# Altium schematic sheet RES + EBS V2.SchDoc
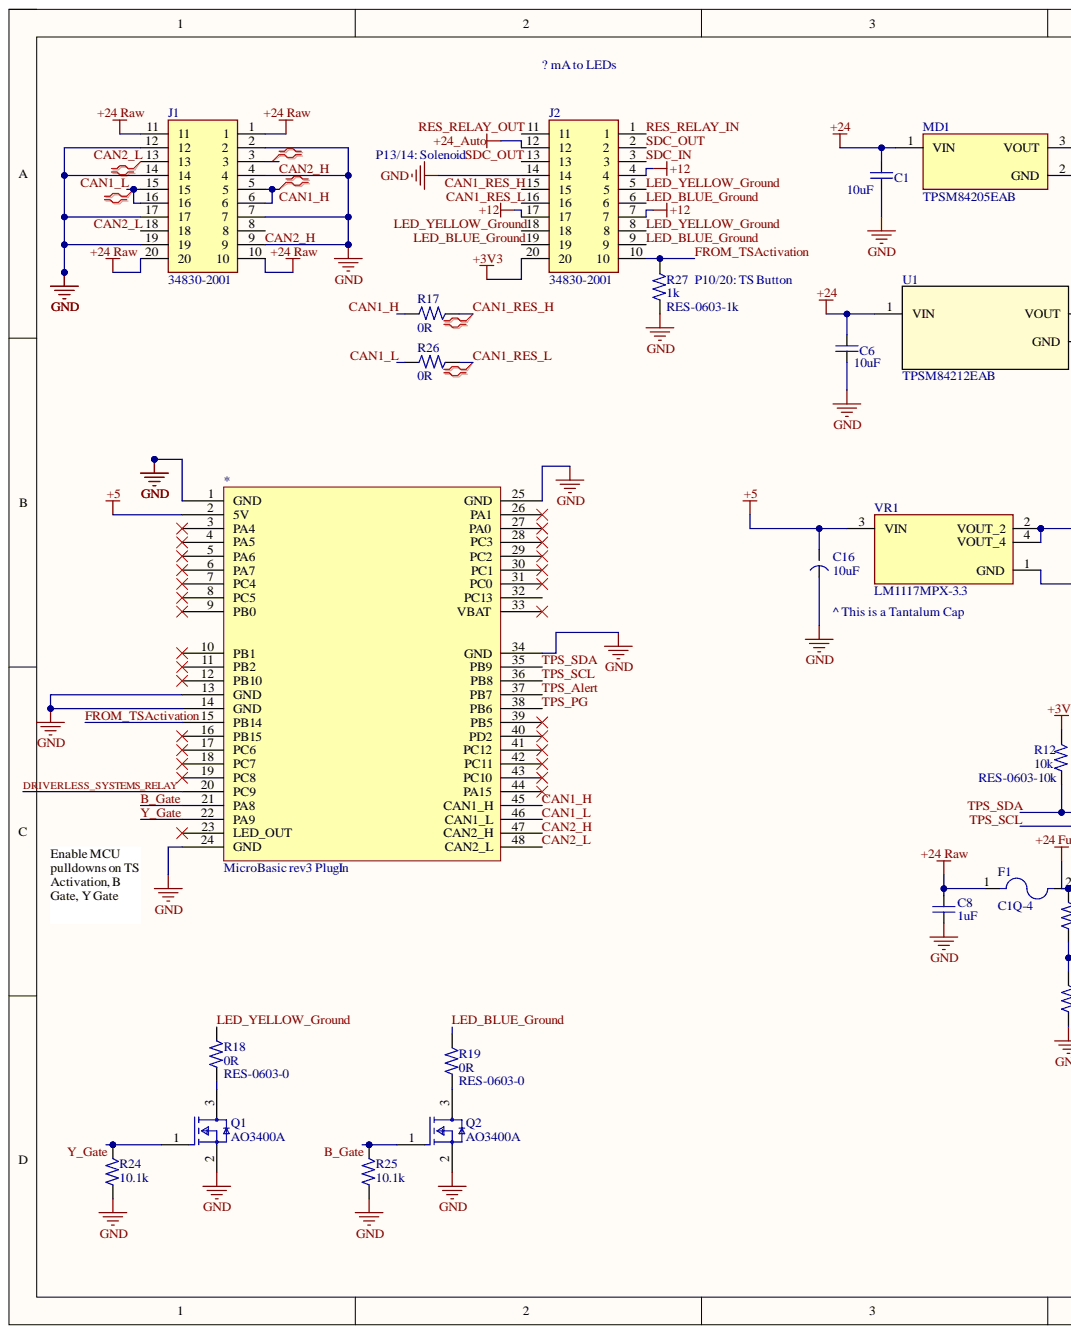
[diagram p0: RES + EBS V2.SchDoc, left]
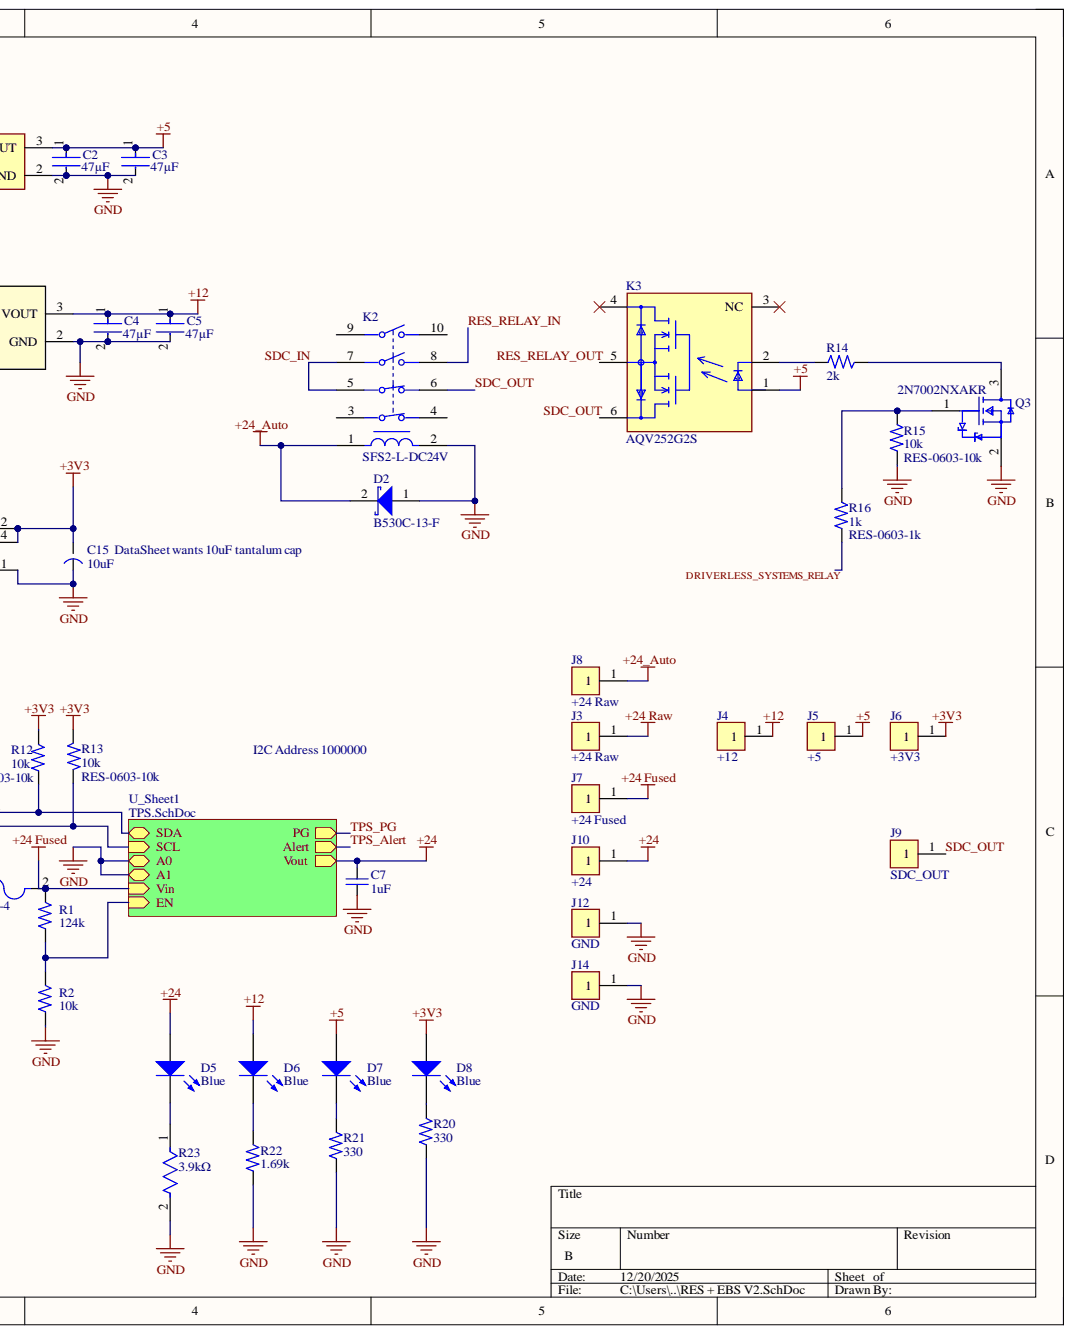
[diagram p1: RES + EBS V2.SchDoc, right]

## Parts
*  MicroBasic rev3 PlugIn  [SFFSTM]
C1  10uF  [C1210]
C2  value 47µF  [FP-GRM32E-0_3-0_2-IPC_C]  (hidden comment: GRM32EC81C476KE15L)
C3  value 47µF  [FP-GRM32E-0_3-0_2-IPC_C]  (hidden comment: GRM32EC81C476KE15L)
C4  value 47µF  [FP-GRM32E-0_3-0_2-IPC_C]  (hidden comment: GRM32EC81C476KE15L)
C5  value 47µF  [FP-GRM32E-0_3-0_2-IPC_C]  (hidden comment: GRM32EC81C476KE15L)
C6  10uF  [C1210]
C7  value 1uF  [CAP0603]  (hidden comment: CAP-0603-1u-50V)
C8  value 1uF  [CAP0603]  (hidden comment: CAP-0603-1u-50V)
C15  value 10uF  Capacitance: 10uF  [FP-TAJA-MFG]  (hidden comment: CAP-TANT-1206-10u-16V)
C16  value 10uF  Capacitance: 10uF  [FP-TAJA-MFG]  (hidden comment: CAP-TANT-1206-10u-16V)
D2  B530C-13-F  [DIOD-SMC-2_V]
D5  Blue  [LED0603]
D6  Blue  [LED0603]
D7  Blue  [LED0603]
D8  Blue  [LED0603]
F1  C1Q-4  [FUSC3215X78N]
J1  34830-2001  [348302001]
J2  34830-2001  [348302001]
J3  +24 Raw  [FP-5001-MFG]
J4  +12  [FP-5001-MFG]
J5  +5  [FP-5001-MFG]
J6  +3V3  [FP-5001-MFG]
J7  +24 Fused  [FP-5001-MFG]
J8  +24 Raw  [FP-5001-MFG]
J9  SDC_OUT  [FP-5001-MFG]
J10  +24  [FP-5001-MFG]
J12  GND  [FP-5001-MFG]
J14  GND  [FP-5001-MFG]
K2  SFS2-L-DC24V  [FP-SFS2-L-DC24V-MFG]
K3  AQV252G2S  [FP-AQV252G2S-IPC_A]
MD1  TPSM84205EAB  [FP-EAB0003A-MFG]
Q1  AO3400A  [FP-SOT23-MFG]
Q2  AO3400A  [FP-SOT23-MFG]
Q3  2N7002NXAKR  [FP-SOT23-IPC_B]
R1  value 124k  [RES0603]  (hidden comment: RES-0603-124k)
R2  RES-0603-10k  value 10k  [RES0603]
R12  RES-0603-10k  value 10k  [RES0603]
R13  RES-0603-10k  value 10k  [RES0603]
R14  value 2k  [RES0603]  (hidden comment: RES-0603-2k)
R15  RES-0603-10k  value 10k  [RES0603]
R16  RES-0603-1k  value 1k  [RES0603]
R17  RES-0603-0  value 0R  [RES0603]
R18  RES-0603-0  value 0R  [RES0603]
R19  RES-0603-0  value 0R  [RES0603]
R20  value 330  [RES0603]  (hidden comment: RES-0603-330)
R21  value 330  [RES0603]  (hidden comment: RES-0603-330)
R22  value 1.69k  [RES0603]  (hidden comment: RES-0603-1.69k)
R23  value 3.9kΩ  [FP-ESR03-IPC_A]  (hidden comment: ESR03EZPF3901)
R24  value 10.1k  [RES0603]  (hidden comment: RES-0603-10.1k)
R25  value 10.1k  [RES0603]  (hidden comment: RES-0603-10.1k)
R26  RES-0603-0  value 0R  [RES0603]
R27  RES-0603-1k  value 1k  [RES0603]
U1  TPSM84212EAB  [EAB0003A]
VR1  LM1117MPX-3.3  [VREG_LM1117MPX-3.3]

## Nets
+3V3: C15.2 D8.1 J2.20 J6.1 R12.2 R13.2 VR1.2(VOUT_2) VR1.4(VOUT_4)
+5: *.2(5V) C2.1 C3.1 C16.2 D7.1 J5.1 K3.1 MD1.3 VR1.3(VIN)
+12: C4.1 C5.1 D6.1 J2.4 J2.7 J2.17 J4.1 U1.3
+24: C1.1 C6.1 C7.1 D5.1 J10.1 MD1.1 U1.1
+24 Fused: F1.2 J7.1 R1.2
+24 Raw: C8.1 F1.1 J1.1 J1.10 J1.11 J1.20 J3.1
+24_Auto: D2.2 J2.12 J8.1 K2.1
GND: *.1(GND) *.13(GND) *.14(GND) *.24(GND) *.25(GND) *.34(GND) C1.2 C2.2 C3.2 C4.2 C5.2 C6.2 C7.2 C8.2 C15.1 C16.1 D2.1 J1.2 J1.4 J1.7 J1.9 J1.12 J1.14 J1.17 J1.19 J2.14 J12.1 J14.1 K2.2 MD1.2 Q1.2 Q2.2 Q3.2 R2.1 R15.1 R20.1 R21.1 R22.1 R23.2 R24.1 R25.1 R27.1 U1.2 VR1.1(GND)
B_Gate: *.21(PA8) Q2.1 R25.2
CAN1_H: *.45(CAN1_H) J1.5 J1.6 R17.1
CAN1_L: *.46(CAN1_L) J1.15 J1.16 R26.1
CAN1_RES_H: J2.15 R17.2
CAN1_RES_L: J2.16 R26.2
CAN2_H: *.47(CAN2_H) J1.3 J1.8
CAN2_L: *.48(CAN2_L) J1.13 J1.18
DRIVERLESS_SYSTEMS_RELAY: *.20(PC9) R16.1
FROM_TSActivation: *.15(PB14) J2.10 R27.2
LED_BLUE_Ground: J2.6 J2.9 J2.19 R19.2
LED_YELLOW_Ground: J2.5 J2.8 J2.18 R18.2
RES_RELAY_IN: J2.1 K2.8
RES_RELAY_OUT: J2.11 K3.5
SDC_IN: J2.3 K2.5 K2.7
SDC_OUT: J2.2 J2.13 J9.1 K2.6 K3.6
TPS_Alert: *.37(PB7)
TPS_PG: *.38(PB6)
TPS_SCL: *.36(PB8) R13.1
TPS_SDA: *.35(PB9) R12.1
Y_Gate: *.22(PA9) Q1.1 R24.2
Net*_3: *.3(PA4)
Net*_4: *.4(PA5)
Net*_5: *.5(PA6)
Net*_6: *.6(PA7)
Net*_7: *.7(PC4)
Net*_8: *.8(PC5)
Net*_9: *.9(PB0)
Net*_10: *.10(PB1)
Net*_11: *.11(PB2)
Net*_12: *.12(PB10)
Net*_16: *.16(PB15)
Net*_17: *.17(PC6)
Net*_18: *.18(PC7)
Net*_19: *.19(PC8)
Net*_23: *.23(LED_OUT)
Net*_26: *.26(PA1)
Net*_27: *.27(PA0)
Net*_28: *.28(PC3)
Net*_29: *.29(PC2)
Net*_30: *.30(PC1)
Net*_31: *.31(PC0)
Net*_32: *.32(PC13)
Net*_33: *.33(VBAT)
Net*_39: *.39(PB5)
Net*_40: *.40(PD2)
Net*_41: *.41(PC12)
Net*_42: *.42(PC11)
Net*_43: *.43(PC10)
Net*_44: *.44(PA15)
NetD5_2: D5.2 R23.1
NetD6_2: D6.2 R22.2
NetD7_2: D7.2 R21.2
NetD8_2: D8.2 R20.2
NetK2_3: K2.3
NetK2_4: K2.4
NetK2_9: K2.9
NetK2_10: K2.10
NetK3_2: K3.2 R14.1
NetK3_3: K3.3(NC)
NetK3_4: K3.4
NetQ1_3: Q1.3 R18.1
NetQ2_3: Q2.3 R19.1
NetQ3_1: Q3.1 R15.2 R16.2
NetQ3_3: Q3.3 R14.2
NetR1_1: R1.1 R2.2

## Library data of the parts
* = CMP-266-000039-10: SN4 MicroBasic PlugIn w/ STM32F446RE, CAN Transcievers, Split Termination and 5-3V3 regulator; Manufacturer Part Number (Female 15P): 2RS1-15-G; Manufacturer Part Number (Female 20P): 2RS1-20-G; Manufacturer Part Number (Male 9P): NRPN091PAEN-RC; Manufacturer Part Number (Male 15P): NRPN151PAEN-RC; Component Kind: Standard; Design Item ID: Component_1; Source: Schlib2.SchLib; Library Name: Formula Electric at Berkeley
C1 C6 = CMP-001-00000-4: CAP CER 10UF 50V X7R 1210; Manufacturer Product Number: CL32B225KCJSNNE; Dissipation Factor: 2.5%; MP: CAP1210; Tolerance: 10%; Voltage Rating (DC): 100V; Availability: Unavailable; Capacitance: 10uF; Case Code (Imperial): 1210; Case Code (Metric): 3225; Case/Package: 1210; Component Kind: Standard; Construction: Flat; Contact Plating: Tin,Matte; Depth: 2.5mm; Design Item ID: CAP1210; Dielectric: X7R; Digi-Key Part Number: 1276-3362-1-ND; Features: Soft Termination; Height: 2.79mm; JLCPCB Part Number: C597564; Lead Free: Lead Free; Length: 3.2mm; Max Operating Temperature: 125°C; Min Operating Temperature: -55°C; Mount: Surface Mount; Number of Pins: 2; Package: None; Package Quantity: 1000; Packaging: Tape and Reel; Price: None; Radiation Hardening: No; Reach SVHC Compliant: Yes; RoHS Compliant: Yes; Series: FLEXITERM®; Source: CAP1210.SchLib; Termination: SMD/SMT; Thickness: 0.037inch; Value: 10uF; Voltage Rating: 50V; Width: 0.098inch; Library Name: Formula Electric at Berkeley
C2-C5 = CMP-292-000400-1: 1210 16 V 47 uF ±10% Tolerance X6S Multilayer Ceramic Capacitor; Manufacturer Lifecycle Status: IN PRODUCTION; Voltage: 16V; Case Code (Imperial): 1210; Case Code (Metric): 3225; Case/Package: 1210; Component Kind: Standard; Depth: 2.5mm; Dielectric: X6S; Dielectric Material: Ceramic; Height: 2.5mm; Lead Free: Lead Free; Length: 3.2mm; Lifecycle Status: Production; Material: Ceramic; Max Operating Temperature: 105°C; Min Operating Temperature: -55°C; Mounting Technology: Surface Mount; Number of Terminals: 2; Packaging: Cut Tape; REACH SVHC: Yes; RoHS: Compliant; Schedule B: 8532240020, 8532240020; Termination: SMD/SMT; Thickness: 2.5mm; Tolerance: 10%; Voltage Rating: 16V; Voltage Rating (DC): 16V; Weight: 109.99615mg; Width: 2.5mm; Library Name: Formula Electric at Berkeley
C7 = CMP-001-00016-4: 0603 1uF 50V Capacitor; Manufacturer Product Number: CL10A105KA8NNNC; Tolerance: 10%; Voltage: 25V; Component Kind: Standard; Digi-Key Part Number: 1276-1102-1-ND; JLCPCB Part Number: C15849; Mouser Part Number: 187-CL10A105KA8NNNC; Source: CAP0603.SchLib; Library Name: Formula Electric at Berkeley
C8 = CMP-001-00016-4: 0603 50V 1uF Capacitor; Manufacturer Product Number: CL10A105KA8NNNC; Tolerance: 10%; Voltage: 25V; Component Kind: Standard; Digi-Key Part Number: 1276-1102-1-ND; JLCPCB Part Number: C15849; Mouser Part Number: 187-CL10A105KA8NNNC; Source: CAP0603.SchLib; Library Name: Formula Electric at Berkeley
C15 C16 = CMP-001-00018-2: CAP TANT 10UF 10% 16V 1206; Manufacturer Product Number: TAJA106K016RNJ; Dielectric Material: Tantalum; Dissipation Factor: 8%; ESR (Equivalent Series Resistance): 3R; Leakage Current: 1.6uA; MP: CAP0603; Polarity: Polar; Availability: Unavailable; Case Code (Imperial): 1206; Case Code (Metric): 3216; Case/Package: 1206; Component Kind: Standard; Construction: Flat; Depth: 1.6mm; Design Item ID: CAP0603; Digi-Key Part Number: 478-1655-1-ND; Features: General Purpose; Height: 1.6mm; Height - Seated (Max): 0.071inch; JLCPCB Part Number: C7171; Lead Free: Lead Free; Lead Pitch: 1.1mm; Length: 3.2mm; Max Operating Temperature: 125°C; Min Operating Temperature: -55°C; Mount: Surface Mount,PCB; Number of Pins: 2; Package: None; Package Quantity: 1; Packaging: Tape and Reel; Price: None; Radiation Hardening: No; Reach SVHC Compliant: Yes; RoHS Compliant: Yes; Series: TAJ; Size: 1.6mm; Source: CAP0603.SchLib; Termination: SMD/SMT; Tolerance: 10%; Voltage: 16V; Voltage Rating: 16V; Voltage Rating (DC): 16V; Width: 1.6mm; Library Name: Formula Electric at Berkeley
D2 = CMP-307-000047-1: Rectifier Diode, Schottky, 1 Phase, 1 Element, 5A, 30V V(RRM), Silicon; Component Kind: Standard; Library Name: Formula Electric at Berkeley
D5-D8 = CMP-004-00002-3: Blue 0603 SMD LED; Manufacturer Product Number: LTST-C191TBKT; Component Kind: Standard; Component Type: Standard; Design Item ID: LED-0603; Digi-Key Part Number: 160-1647-1-ND; JLCPCB Part Number: C99290; JLCPCB Part Type: Extended; Mouser Part Number: 859-LTST-C191TBKT; Pin Count: 2; Simulation: LED1; Source: LED0603.SchLib; Library Name: Formula Electric at Berkeley
F1 = CMP-290-000000-3: FUSE BOARD MOUNT 4A 125VAC 63VDC; Availability: Unavailable; Component Kind: Standard; Component Type: Standard; Design Item ID: C1Q-4; Digi-Key Part Number: 507-1084-1-ND; Manufacturer Product Number: C1Q-4; Mouser Part Number: 530-C1Q4; MP: C1Q-4; Package: None; Pin Count: 2; Price: None; Source: C1Q-4.SchLib; Library Name: Formula Electric at Berkeley
J1 J2 = CMP-253-000017-1: Automotive Connectors MX150 RA HDR 2X10 ST BLK KEY A; Manufacturer_Name: Molex; Component Kind: Standard; Datasheet Link: https://www.molex.com/webdocs/datasheets/pdf/en-us/0348302001_PCB_HEADERS.pdf; Design Item ID: 34830-2001; Height: 25.42mm; Manufacturer_Part_Number: 34830-2001; Mouser Part Number: 538-34830-2001; Mouser Price/Stock: https://www.mouser.co.uk/ProductDetail/Molex/34830-2001?qs=PdnAIJ6Mlf3cw4zbnnbtng%3D%3D; Source: SamacSys.SchLib; Library Name: Formula Electric at Berkeley
J3-J10 J12 J14 = CMP-504-000001-1: PC TEST POINT MINIATURE BLACK; Manufacturer: Keystone Electronics; Manufacturer Part Number: 5001; Body Material: Bronze; Hole Diameter: 1.6mm; Inside Diameter: 1.27mm; Insulation: Insulated; Number of Contacts: 1; Outside Diameter: 2.54mm; Plating: Silver; Color: Black; Component Kind: Standard; Contact Material: Bronze; Contact Plating: Silver; Design Item ID: CMP-2000-05181-2; Diameter: 2.54mm; Flammability Rating: UL94 V-0; Height: 0.3inch; Housing Color: Black; Insulation Material: Nylon; Lead Free: Lead Free; Length: 7.62mm; Material: Nylon; Mount: Through Hole; Number of Ports: 1; Orientation: Straight; Package Quantity: 100; Packaging: Bulk; REACH SVHC: No SVHC; RoHS Compliant: Yes; Series: THM; Source: PV Buck v2.SCHLIB; Termination: Through Hole; Library Name: Formula Electric at Berkeley
K2 = CMP-00101-1: Safety Relay, 4PST, Momentary, 0.015A (Coil), 24VDC (Coil), 360mW (Coil), 6A (Contact), 30VDC (Contact), DC Input, AC/DC Output, Through Hole-Straight Mount; Body Breadth: 13mm; Body Height: 24mm; Body Length or Diameter: 50mm; Coil Current(DC)-Max: 15mA; Coil Operate Voltage(DC): 18V; Coil Release Voltage(DC): 2.4V; Coil Resistance: 1.6kR; Coil Voltage(DC)-Max: 24V; Contact AC Max Rating R Load: 6A@250VAC; Contact DC Max Rating R Load: 6A@30VDC; Contact Voltage(AC)-Max: 250V; Contact Voltage(DC)-Max: 30V; Operate Time: 20ms; Reference Standard: UL; Coil Power: 360mW; Coil/Input Supply Type: DC; Component Kind: Standard; Contact Current(AC)-Max: 6A; Contact Current(DC)-Max: 6A; Contact/Output Supply Type: AC/DC; Max Operating Temperature: 85°C; Min Operating Temperature: -40°C; Mounting Technology: Through Hole; Packaging: Box; Reach SVHC Compliant: Yes; Relay Action: MOMENTARY; Relay Function: 4PST; Relay Type: Safety RELAY; Release Time: 20ms; RoHS Compliant: Yes; Termination: Solder; Library Name: Formula Electric at Berkeley
K3 = CMP-00112-1: AQV Series SPST-NO 3 A 50 V Solid State Relay - SOIC-6; Data Polarity: AC/DC; Forward Current: 30mA; Input Current: 600µA; Isolation Voltage: 1.5kV; Load Current: 3A; Max Power Dissipation: 500mW; Number of Contacts: 1; On-State Resistance: 70mΩ; Output Voltage: 40V; Throw Configuration: SPST; Value: 70mΩ; Ambient Temperature Range High: 85°C; Case/Package: SOP; Component Kind: Standard; Height: 2mm; Lead Free: Lead Free; Length: 6.3mm; Lifecycle Status: Production; Max Length: 6.3mm; Max Operating Temperature: 85°C; Min Operating Temperature: -40°C; Mounting Technology: Surface Mount; Number of Elements: 1; Output Current: 3A; Pins: 6; REACH SVHC: Yes; Relay Type: Relay; RoHS: Compliant; Termination: Gull Wing; Width: 4.4mm; Library Name: Formula Electric at Berkeley
MD1 = CMP-04918-000338-1: DC DC CONVERTER 5V; Efficiency: 94%; Max Input Voltage: 28V; Max Output Current: 1.5A; Min Input Voltage: 7V; Number of Outputs: 1; Case/Package: TO-220-3; Component Kind: Standard; Features: OVP; Library Name: Altium Content Vault; Max Operating Temperature: 125°C; Min Operating Temperature: -40°C; Mount: Through Hole; Packaging: Tray; RoHS Compliant: Yes; Voltage - Output 1: 5V
Q1 Q2 = CMP-83955-000006-1: MOSFET N-CH 30V 5.7A SOT23; Gate to Source Voltage (Vgs): 12V; Input Capacitance: 630pF; Max Power Dissipation: 1.4W; Number of Elements: 1; Rds On Max: 26.5mR; Case/Package: SOT-23; Component Kind: Standard; Continuous Drain Current (ID): 5.7A; Drain to Source Voltage (Vdss): 30V; Lead Free: Lead Free; Library Name: Altium Content Vault; Max Operating Temperature: 150°C; Min Operating Temperature: -55°C; Mount: Surface Mount; Number of Pins: 3; Packaging: Tape and Reel; Power Dissipation: 1.4W; Radiation Hardening: No
Q3 = CMP-343-000000-4: MOSFET N-CH 60V 190MA SOT23-5; Component Kind: Standard; Digi-Key Part Number: 1727-8643-1-ND; JLCPCB Part Number: C551416; JLCPCB Part Type: Extended; Manufacturer Product Number: 2N7002NXAKR; Mouser Part Number: 771-2N7002NXAKR; Library Name: Formula Electric at Berkeley
R1 = CMP-009-00011-2: 0603 124kOhm Resistor; Manufacturer Product Number: AC0603FR-07124KL; Max Power Dissipation: 100mW; MP: 3-2176326-0; Resistance: 124kR; Temperature Coefficient: 100ppm/°C; Tolerance: 1%; Voltage Rating: 50V; Availability: Unavailable; Case Code (Imperial): 0603; Case Code (Metric): 1608; Case/Package: 0603; Component Kind: Standard; Design Item ID: 3-2176326-0; Digi-Key Part Number: 13-AC0603FR-07124KLCT-ND; Features: Moisture Resistant,Automotive AEC-Q200; Height: 0.022inch; JLCPCB Part Number: C227568; JLCPCB Part Type: Extended; Length: 0.063inch; Max Operating Temperature: 155°C; Min Operating Temperature: -55°C; Number of Terminations: 2; Package: None; Packaging: Tape and Reel; Power Rating: 100mW; Price: None; Resistor Type: Thick Film; Series: AC; Source: RES0603.SchLib; Termination: SMD/SMT; Width: 0.8mm; Library Name: Formula Electric at Berkeley
R2 = CMP-009-00008-4: Resistor 0603 10k Ohm 1% 1/8W; Manufacturer Product Number: RNCP0603FTD10K0; Component Kind: Standard; Digi-Key Part Number: RNCP0603FTD10K0CT-ND; JLCPCB Part Number: C25804; Mouser Part Number: 708-RNCP0603FTD10K0; Source: RES0603.SchLib; Tolerance: 1%; Library Name: Formula Electric at Berkeley
R12 R13 R15 = CMP-009-00027-4: 10kOhm 0603 Resistor; Manufacturer Product Number: ERJ-3GEYJ103V; Component Kind: Standard; Digi-Key Part Number: RNCP0603FTD10K0CT-ND; JLCPCB Part Number: C25804; Mouser Part Number: 667-ERJ-3GEYJ103V; Source: RES0603.SchLib; Library Name: Formula Electric at Berkeley
R14 = CMP-009-00039-2: 2kOhm 0603 Resistor; Manufacturer Product Number: RC0603FR-072KL; Availability: Unavailable; Component Kind: Standard; Digi-Key Part Number: 311-2.00KHRCT-ND; JLCPCB Part Number: C22975; Mouser Part Number: 603-RC0603FR-072KL; MP: RC0603FR-072KL; Package: None; Price: None; Source: RES0603.SchLib; Library Name: Formula Electric at Berkeley
R16 R27 = CMP-009-00012-2: 0603 1kOhm Resistor; Manufacturer Product Number: RC1608F102CS; Tolerance: 1%; Voltage Rating: 50V; Component Kind: Standard; Digi-Key Part Number: 1276-3484-1-ND; JLCPCB Part Number: C21190; Source: RES0603.SchLib; Library Name: Formula Electric at Berkeley
R17-R19 R26 = CMP-009-00025-2: Metal Glaze/thick Film, 0.1W, 0ohm, Surface Mount, 0603; Manufacturer Product Number: RC0603JR-070RL; MP: 3-2176326-0; Package Height: 0.45mm; Package Length: 1.6mm; Package Width: 0.8mm; Power: 100mW; Rated Temperature: 70°C; Availability: Unavailable; Case/Package: 0603; Component Kind: Standard; Construction: Chip; Design Item ID: 3-2176326-0; JESD-609 Code: e3; JLCPCB Part Number: C95177; Max Operating Temperature: 155°C; Min Operating Temperature: -55°C; Mounting Technology: Surface Mount; Package: None; Package Shape: Rectangular; Package Style: SMTMeter; Packaging: Tape and Reel; Pins: 2; Price: None; Reach SVHC Compliant: Yes; Resistor Type: FIXED RESISTOR; RoHS Compliant: Yes; Source: RES0603.SchLib; Technology: METAL GLAZE/THICK FILM; Terminal Finish: Nickel; Termination: Wraparound; Library Name: Formula Electric at Berkeley
R20 R21 = CMP-009-00005-3: 330 Ohm 0603 Resistor; Manufacturer Product Number: RMCF0603FT330R; Tolerance: 1%; Component Kind: Standard; Digi-Key Part Number: RMCF0603FT330RCT-ND; JLCPCB Part Number: C23138; Mouser Part Number: 708-RMCF0603FT330R; Package: 0603; Source: RES0603.SchLib; Library Name: Formula Electric at Berkeley
R22 = CMP-009-00020-2: RES 1.69K OHM 1% 1/10W 0603; Manufacturer Part Number: RC0603FR-071K69L; Max Power Dissipation: 100mW; MP: 3-2176326-0; Resistance: 1.69kR; Temperature Coefficient: 100ppm/°C; Voltage Rating (DC): 50V; Availability: Unavailable; Case Code (Imperial): 0603; Case Code (Metric): 1608; Case/Package: 0603; Component Kind: Standard; Construction: Rectangular; Depth: 0.8mm; Design Item ID: 3-2176326-0; Digi-Key Part Number: 311-1.69KHRCT-ND; Digi-Key_Part_Number: 311-1.69KHRCT-ND; Digi-Key_Purchase_URL: https://www.digikey.com/en/products/detail/yageo/RC0603FR-071K69L/726871?s=N4IgTCBcDaIEoGEAMA2JBmAYnAtEg7AIwDSKAnADIgC6AvkA; Features: Moisture Resistant; Height: 0.022inch; JLCPCB Part Number: C185356; JLCPCB Part Type: Extended; Lead Free: Lead Free; Length: 1.6mm; Max Operating Temperature: 155°C; Military Standard: Not; Min Operating Temperature: -55°C; Number of Terminations: 2; Package: None; Package Quantity: 1; Packaging: Tape and Reel; Power Rating: 100mW; Price: None; Resistor Type: Thick Film; RoHS Compliant: Yes; Series: RC; Size Code: 0603; Source: RES0603.SchLib; Tolerance: 1%; Width: 0.031inch; Library Name: Formula Electric at Berkeley
R23 = CMP-397-000294-1: Res Thick Film 0603 3.9K Ohm 1% 0.33W(1/3W) ±100ppm/C Pad SMD T/R Automotive AEC-Q200; Max Power Dissipation: 250mW; Temperature Coefficient: 100ppm/°C; Voltage Rating: 150V; Case/Package: 0603; Component Kind: Standard; Composition: Thick Film; Height: 450µm; Length: 1.6002mm; Lifecycle Status: Production; Max Operating Temperature: 155°C; Min Operating Temperature: -55°C; Mounting Technology: Surface Mount; Number of Terminals: 2; Number of Terminations: 2; Packaging: Tape & Reel (TR); Power Dissipation: 250mW; Power Rating: 250mW; REACH SVHC: Yes; RoHS: Compliant; Tolerance: 1%; Width: 800µm; Working Voltage: 150V; Library Name: Formula Electric at Berkeley
R24 R25 = CMP-397-000176-1: 0603 10.1kOhm Resistor; Manufacturer Product Number: RT0603DRE0710K1L; Power: 1/10W; Tolerance: 0.5%; Component Kind: Standard; Digi-Key Part Number: 13-RT0603DRE0710K1LCT-ND; Digi-Key_Purchase_URL: https://www.digikey.com/en/products/detail/yageo/RT0603DRE0710K1L/7708396; JLCPCB Part Type: Basic/Extended; Package: 0603; Source: RES0603.SchLib; Library Name: Formula Electric at Berkeley
U1 = CMP-889-000001-1: No Description Available; Mfr_Name: Texas Instruments; Component Kind: Standard; Design Item ID: TPSM84212EAB; RefDes: RefDes; Source: TPSM84212EAB.SchLib; TYPE: TYPE; Library Name: Formula Electric at Berkeley
VR1 = CMP-151-000000-10: 800-mA 15-V linear voltage regulator 4-SOT-223; Adjustability: FIXED; Channels: 1; Dropout Voltage1-Max: 1.3V; Dropout Voltage1-Nom: 1.2V; Input Voltage Absolute-Max: 20V; Line Regulation-Max: 0.006%; Load Regulation-Max: 0.01%; Max Supply Voltage: 15V; MF: Texas Instruments; Min Input Voltage: 4.75V; Output Current1-Max: 800mA; Output Voltage1-Max: 3.365V; Output Voltage1-Min: 3.235V; Output Voltage1-Nom: 3.3V; Package Body Material: Plastic; Qualification Status: Not Qualified; Regulator Type: FIXED POSITIVE SINGLE OUTPUT LDO REGULATOR; Time @ Peak Reflow Temperature-Max (s): 40s; Voltage Tolerance-Max: 2%; Availability: Warning; Case/Package: SOP; Component Kind: Standard; Design Item ID: LM1117MPX-3.3; Digi-Key Part Number: LM1117MPX-3.3/NOPBCT-ND; Height - Seated (Max): 1.8mm; JESD-30 Code: R-PDSO-G4; JESD-609 Code: e3; JLCPCB Part Number: C2866035; JLCPCB Part Type: Extended; Length: 6.5mm; Moisture Sensitivity Level: 1; Mouser Part Number: 926-LM1117MPX-33; Mouser-Purchase-URL: https://snapeda.com/shop?store=Mouser&id=78946; MP: LM1117MPX-3.3; Number of Outputs: 1; Operating Temperature, TJ-Max: 125°C; Operating Temperature, TJ-Min: 0°C; Package: SOT-223-4 Texas Instruments; Package Shape: Rectangular; Package Style: SMALL OUTLINEMeter; Packaging: Tape and Reel; Peak Reflow Temperature (Cel): 260°C; Pins: 4; Price: None; Reach SVHC Compliant: Yes; RoHS Compliant: Yes; SnapEDA_Link: https://www.snapeda.com/parts/LM1117MPX-3.3/Texas%20Instruments/view-part/78946/?ref=snap; Source: LM1117MPX-3.3.SchLib; Surface Mount: Yes; Technology: BIPOLAR; Terminal Finish: Tin; Terminal Form: Gull Wing; Terminal Pitch: 2.3mm; Terminal Position: DUAL; Texas_Instruments-Purchase-URL: https://snapeda.com/shop?store=Texas+Instruments&id=78946; Width: 3.56mm; Library Name: Formula Electric at Berkeley
